# Altium schematic sheet IPMS 2.3 Module LCD.SchDoc("IPMS 2.3 Module LCD")
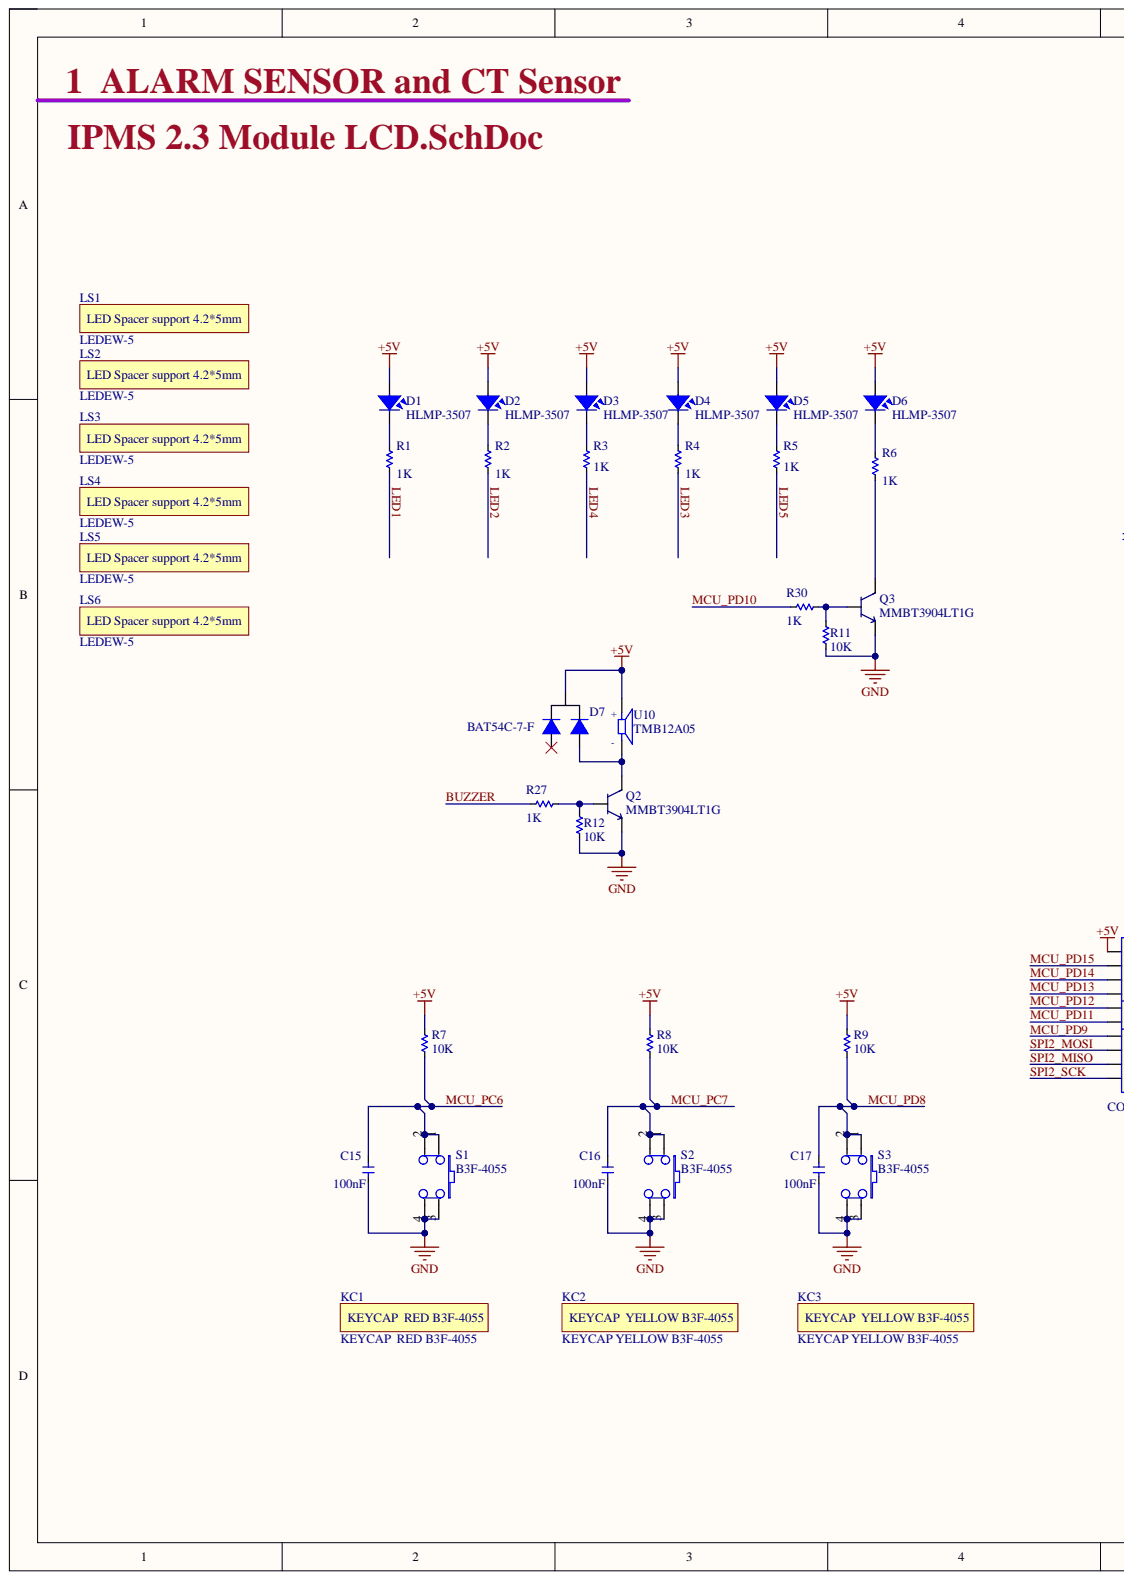
[diagram p0: IPMS 2.3 Module LCD.SchDoc, left]
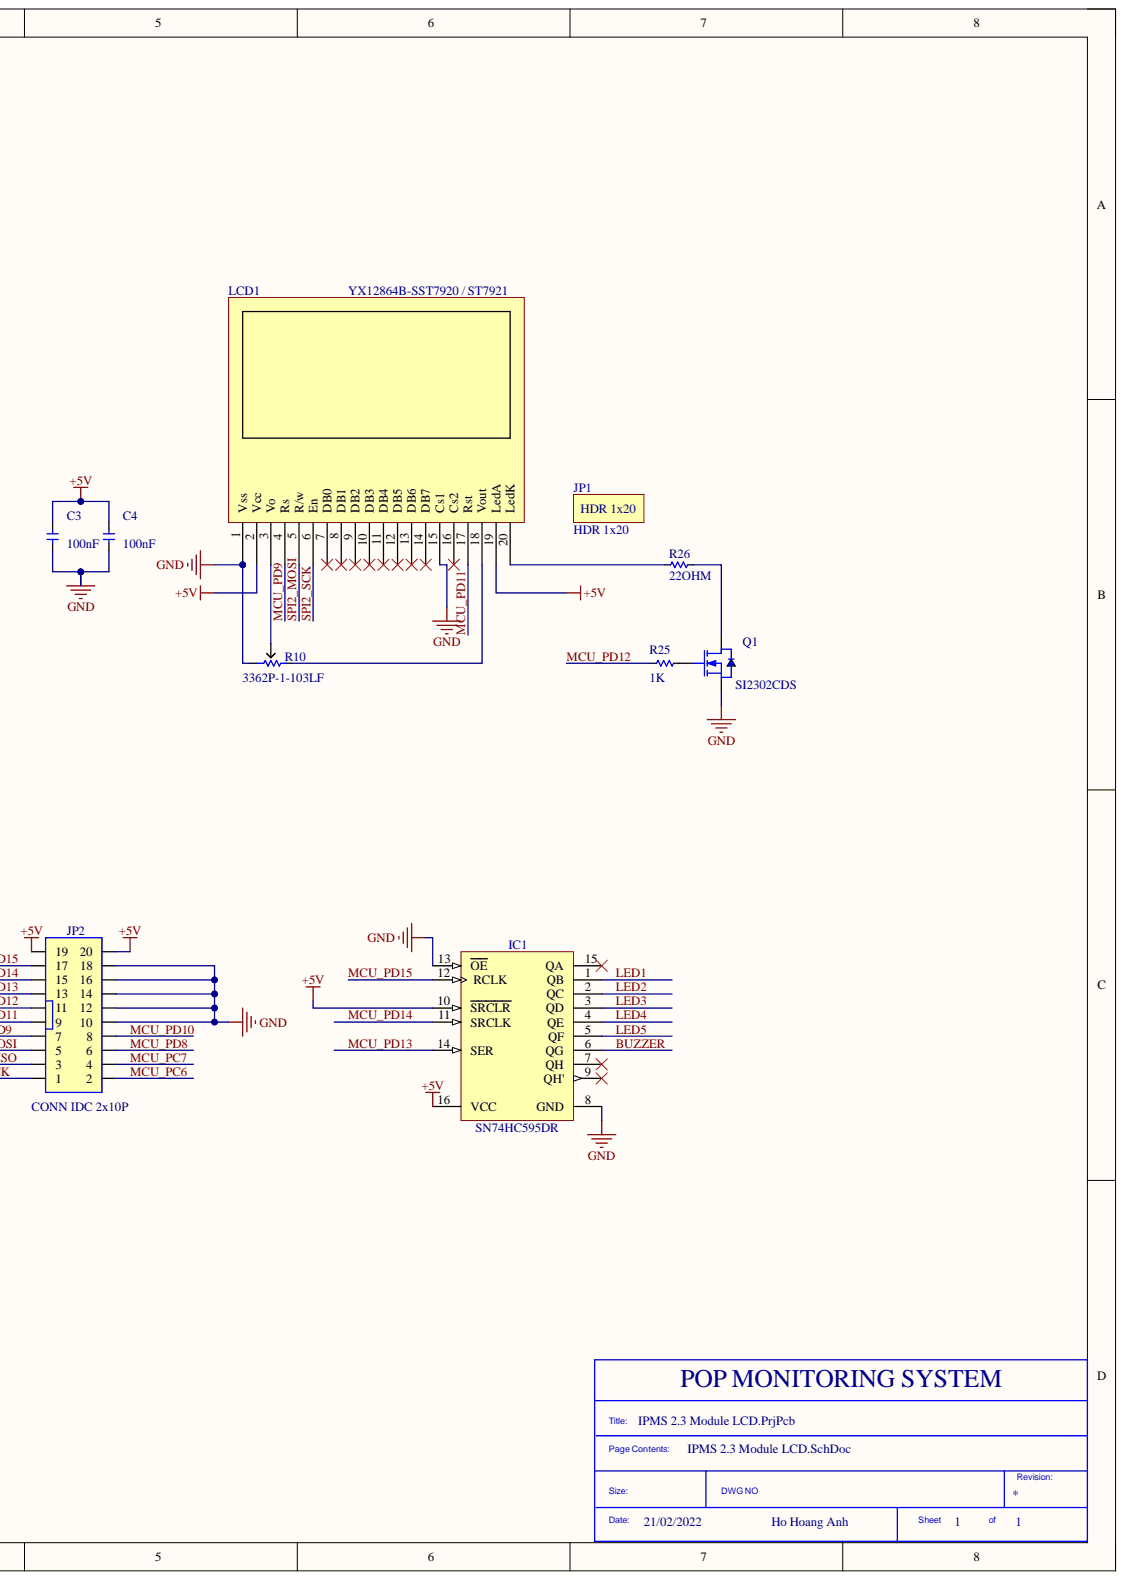
[diagram p1: IPMS 2.3 Module LCD.SchDoc, right]

## Parts
C3  100nF  [C_0603]
C4  100nF  [C_0603]
C15  100nF  [C_0603]
C16  100nF  [C_0603]
C17  100nF  [C_0603]
D1  HLMP-3507  [LED_5MM_GRE]
D2  HLMP-3507  [LED_5MM_GRE]
D3  HLMP-3507  [LED_5MM_GRE]
D4  HLMP-3507  [LED_5MM_GRE]
D5  HLMP-3507  [LED_5MM_GRE]
D6  HLMP-3507  [LED_5MM_GRE]
D7  BAT54C-7-F  [SOT-23-3]
IC1  SN74HC595DR  [SOIC-16_3.9x9.9x1.27P]
JP1  HDR 1x20  [HDR1X20]
JP2  CONN IDC 2x10P  [IDC-TH_20P-P2.54-V-R2-C10-S2.54]
KC1  KEYCAP  RED B3F-4055
KC2  KEYCAP YELLOW B3F-4055  Manufacturer Part Number: KEYCAP  YELLOW B3F-4055
KC3  KEYCAP YELLOW B3F-4055  Manufacturer Part Number: KEYCAP  YELLOW B3F-4055
LCD1  YX12864B-SST7920 / ST7921  [GLCD_12864B]
LS1  LEDEW-5  [Led Spacer support 4.2*5mm]
LS2  LEDEW-5  [Led Spacer support 4.2*5mm]
LS3  LEDEW-5  [Led Spacer support 4.2*5mm]
LS4  LEDEW-5  [Led Spacer support 4.2*5mm]
LS5  LEDEW-5  [Led Spacer support 4.2*5mm]
LS6  LEDEW-5  [Led Spacer support 4.2*5mm]
Q1  SI2302CDS  [SOT-23-3]
Q2  MMBT3904LT1G  [SOT-23-3]
Q3  MMBT3904LT1G  [SOT-23-3]
R1  1K  [R_0603]
R2  1K  [R_0603]
R3  1K  [R_0603]
R4  1K  [R_0603]
R5  1K  [R_0603]
R6  1K  [R_0603]
R7  10K  [R_0603]
R8  10K  [R_0603]
R9  10K  [R_0603]
R10  3362P-1-103LF  [RES-ADJ-TH_3362P]
R11  10K  [R_0603]
R12  10K  [R_0603]
R25  1K  [R_0603]
R26  22OHM  [R_1206]
R27  1K  [R_0603]
R30  1K  [R_0603]
S1  B3F-4055  [KEY-TH_4P-L12.0-W12.0-P5.08-LS12.50]
S2  B3F-4055  [KEY-TH_4P-L12.0-W12.0-P5.08-LS12.50]
S3  B3F-4055  [KEY-TH_4P-L12.0-W12.0-P5.08-LS12.50]
U10  TMB12A05  [Buzzer_P=7.62 12mm]

## Nets
+5V: C3.2 C4.2 D1.1 D2.1 D3.1 D4.1 D5.1 D6.1 D7.3 IC1.10(SRCLR) IC1.16(VCC) JP2.19 JP2.20 LCD1.2(Vcc) LCD1.19(LedA) R7.1 R8.1 R9.1 U10.P
GND: C3.1 C4.1 C15.2 C16.2 C17.2 IC1.8(GND) IC1.13(OE) JP2.10 JP2.12 JP2.14 JP2.16 JP2.18 LCD1.1(Vss) LCD1.15(Cs1) Q1.2 Q2.2 Q3.2 R10.3 R11.2 R12.2 S1.3 S1.4 S2.3 S2.4 S3.3 S3.4
BUZZER: IC1.6(QG) R27.2
LED1: IC1.1(QB) R1.2
LED2: IC1.2(QC) R2.2
LED3: IC1.3(QD) R4.2
LED4: IC1.4(QE) R3.2
LED5: IC1.5(QF) R5.2
MCU_PC6: C15.1 JP2.2 R7.2 S1.1 S1.2
MCU_PC7: C16.1 JP2.4 R8.2 S2.1 S2.2
MCU_PD8: C17.1 JP2.6 R9.2 S3.1 S3.2
MCU_PD9: JP2.7 LCD1.4(Rs)
MCU_PD10: JP2.8 R30.2
MCU_PD11: JP2.9 LCD1.17(Rst)
MCU_PD12: JP2.11 R25.1
MCU_PD13: IC1.14(SER) JP2.13
MCU_PD14: IC1.11(SRCLK) JP2.15
MCU_PD15: IC1.12(RCLK) JP2.17
SPI2_MISO: JP2.3
SPI2_MOSI: JP2.5 LCD1.5(R/w)
SPI2_SCK: JP2.1 LCD1.6(En)
NetD1_2: D1.2 R1.1
NetD2_2: D2.2 R2.1
NetD3_2: D3.2 R3.1
NetD4_2: D4.2 R4.1
NetD5_2: D5.2 R5.1
NetD6_2: D6.2 R6.1
NetD7_2: D7.2 Q2.3 U10.M
NetLCD1_3: LCD1.3(Vo) R10.2
NetLCD1_18: LCD1.18(Vout) R10.1
NetLCD1_20: LCD1.20(LedK) R26.2
NetQ1_1: Q1.1 R25.2
NetQ1_3: Q1.3 R26.1
NetQ2_1: Q2.1 R12.1 R27.1
NetQ3_1: Q3.1 R11.1 R30.1
NetQ3_3: Q3.3 R6.2

Not connected: D7 1; IC1 7(QH) 9(QH') 15(QA); LCD1 7(DB0) 8(DB1) 9(DB2) 10(DB3) 11(DB4) 12(DB5) 13(DB6) 14(DB7) 16(Cs2)

## Library data of the parts
C3 C4 C15-C17 = CC0603KRX7R9BB104: 100nF 50V X7R ±10% 0603 Multilayer Ceramic Capacitors MLCC - SMD/SMT ROHS; Manufacturer: YAGEO; SMT Assembly: Yes; Component Kind: Standard; LCSC Part Number: C14663; Manufacturer Part Number: CC0603KRX7R9BB104; Package: 0603; Library Name: IPMS 2.3 Module LCD.SCHLIB
D1-D6 = HLMP-3507: LED, 5MM, GREEN, 4.2MCD, 569NM; Manufacturer: Broadcom Avago; SMT Assembly: No; Component Kind: Standard; ComponentLink1Description: http://www.jameco.com/Jameco/Products/ProdDS/253770.pdf?src-supplier=Jameco; ComponentLink1URL: http://www.jameco.com/Jameco/Products/ProdDS/253770.pdf?src-supplier=Jameco; ComponentLink2Description: https://www.verical.com/server-webapp/html/getDatasheet?partID=128035&src-supplier=Verical; ComponentLink2URL: https://www.verical.com/server-webapp/html/getDatasheet?partID=128035&src-supplier=Verical; ComponentLink3Description: http://uk.rs-online.com/webdocs/0026/0900766b80026843.pdf?src-supplier=RSComponents; ComponentLink3URL: http://uk.rs-online.com/webdocs/0026/0900766b80026843.pdf?src-supplier=RSComponents; ComponentLink4Description: https://www.distrelec.biz/Web/Downloads/he/et/HLMP3x01-3507_eng_datasheet.pdf?mime=application%2Fpdf&src-supplier=Distrelec; ComponentLink4URL: https://www.distrelec.biz/Web/Downloads/he/et/HLMP3x01-3507_eng_datasheet.pdf?mime=application%2Fpdf&src-supplier=Distrelec; ComponentLink5Description: http://www.rapidonline.com/pdf/55-2820.pdf?src-supplier=Rapid+Electronics; ComponentLink5URL: http://www.rapidonline.com/pdf/55-2820.pdf?src-supplier=Rapid+Electronics; ComponentLink6Description: http://www.produktinfo.conrad.com/datenblaetter/175000-199999/180622-da-01-en-LED_5_MM_HLMP_3507_GRUEN.pdf?src-supplier=Conrad; ComponentLink6URL: http://www.produktinfo.conrad.com/datenblaetter/175000-199999/180622-da-01-en-LED_5_MM_HLMP_3507_GRUEN.pdf?src-supplier=Conrad; LCSC Part Number: C188723; Manufacturer Part Number: HLMP-3507; Package: Through hole; Library Name: IPMS 2.3 Module LCD.SCHLIB
D7 = BAT54C-7-F: DIODE ARRAY SCHOTTKY 30V SOT23-3; Manufacturer: Diodes; SMT Assembly: Yes; Component Kind: Standard; ComponentLink1Description: https://www.diodes.com/assets/Datasheets/ds11005.pdf?src-supplier=Digi-Key; ComponentLink1URL: https://www.diodes.com/assets/Datasheets/ds11005.pdf?src-supplier=Digi-Key; ComponentLink2Description: http://www.farnell.com/datasheets/1911820.pdf?src-supplier=Element14; ComponentLink2URL: http://www.farnell.com/datasheets/1911820.pdf?src-supplier=Element14; ComponentLink3Description: https://www.verical.com/server-webapp/html/getDatasheet?partID=29884&src-supplier=Verical; ComponentLink3URL: https://www.verical.com/server-webapp/html/getDatasheet?partID=29884&src-supplier=Verical; LCSC Part Number: C134405; Manufacturer Part Number: BAT54C-7-F; Package: SOT-23-3; Library Name: IPMS 2.3 Module LCD.SCHLIB
IC1 = SN74HC595DR: 74HC Shift Register SOIC-16_150mil Registers ROHS; Manufacturer: Texas Instruments; SMT Assembly: Yes; Component Kind: Standard; LCSC Part Number: C10092; Manufacturer Part Number: SN74HC595DR; Package: SOIC-16_3.9x9.9x1.27P; Library Name: IPMS 2.3 Module LCD.SCHLIB
JP1 = 61302011121: Connector Header Through Hole 20 position 0.100" (2.54mm); Manufacturer: Wurth Electronics; SMT Assembly: No; Component Kind: Standard; LCSC Part Number: N/A; Manufacturer Part Number: 61302011121; Package: HDR P=2.54; Library Name: IPMS 2.3 Module LCD.SCHLIB
JP2 = IDC 2X10P Push-Pull: 2.54mm Straight Push - Pull 2.54mm 2 Plugin,P=2.54mm IDC Connectors ROHSM; Manufacturer: BOOMELE(Boom Precision Elec); Manufacturer Part Number: 302-S201; SMT Assembly: No; Component Kind: Standard; LCSC Part Number: C3405; Package: 2.54 2X10P; Library Name: IPMS 2.3 Module LCD.SCHLIB
KC1 = KEYCAP  RED B3F-4055: Keycap red for tact switch B3f-4055 12x12mm; Manufacturer: China; SMT Assembly: No; Component Kind: Standard; LCSC Part Number: N/A; Manufacturer Part Number: KEYCAP  RED B3F-4055; Package: N/A; Library Name: IPMS 2.3 Module LCD.SCHLIB
KC2 KC3 = KEYCAP YELLOW B3F-4055: Keycap yellow for tact switch B3f-4055 12x12mm; Manufacturer: China; SMT Assembly: No; Component Kind: Standard; LCSC Part Number: N/A; Package: N/A; Library Name: IPMS 2.3 Module LCD.SCHLIB
LCD1 = YX12864B: LCD12864B-V2.0 128x64 DOTS, Graphic LCD module, White/ Blue, 5V Parallel / SPI Driver ST7290/ST7291; Manufacturer: TAKAMISAWA; SMT Assembly: No; Component Kind: Standard; LCSC Part Number: N/A; Manufacturer Part Number: YX12864B; Package: Module; Library Name: IPMS 2.3 Module LCD.SCHLIB
LS1-LS6 = LEDEW-5: Photoelectric Accessories LED 3mm Spacer Support 4.2*5mm; Manufacturer: HIWA; SMT Assembly: No; Component Kind: Standard; LCSC Part Number: C116904; Manufacturer Part Number: LEDEW-5; Package: N/A; Library Name: IPMS 2.3 Module LCD.SCHLIB
Q1 = SI2302CDS-T1-GE3: MOSFET N-CH 20V 2.6A SOT-23; Manufacturer: Vishay Siliconix; SMT Assembly: Yes; Component Kind: Standard; LCSC Part Number: C10488; Manufacturer Part Number: Si2302CDS-T1-GE3; Package: SOT-23-3; Library Name: IPMS 2.3 Module LCD.SCHLIB
Q2 Q3 = MMBT3904LT1G: MMBT3904 TRANSISTORS (NPN/PNP) NPN 200MA 40V SOT-23(SOT-23-3) ROHS; Manufacturer: Onsemi; SMT Assembly: Yes; Component Kind: Standard; LCSC Part Number: C81464; Manufacturer Part Number: MMBT3904LT1G; Package: SOT-23-3; Library Name: IPMS 2.3 Module LCD.SCHLIB
R1-R6 R25 R27 R30 = 0603WAF1001T5E: 1KOHMS ±1% 1/10W 0603 ROHS; Manufacturer: Uniroyal Elec; SMT Assembly: Yes; Component Kind: Standard; LCSC Part Number: C21190; Manufacturer 2: Yageo; Manufacturer Part Number: 0603WAF1001T5E; Manufacturer Part Number 2: RC0603FR-071KP; Package: 0603; Pricing 1: 1=0.00210, 10=0.0021 , 100=0.0021, 1000=0.00180 (LCSC); Library Name: IPMS 2.3 Module LCD.SCHLIB
R7-R9 R11 R12 = 0603WAF1002T5E: 10KOHMS ±1% 1/10W 0603 ROHS; Manufacturer: Uniroyal Elec; SMT Assembly: Yes; Component Kind: Standard; LCSC Part Number: C25804; Manufacturer 2: Yageo; Manufacturer Part Number: 0603WAF1002T5E; Manufacturer Part Number 2: RC0603FR-0710KL; Package: 0603; Pricing 1: 1=0.00160, 10=0.00160, 100=0.00160, 1000=0.00130 (LCSC); Library Name: IPMS 2.3 Module LCD.SCHLIB
R10 = 3362P-1-103LF: 10K ±10% ±100ppm/℃ 3362P Precision Potentiometer RoHS; Manufacturer: BOURNS; SMT Assembly: No; Component Kind: Standard; Datasheet: https://datasheet.lcsc.com/szlcsc/1811151535_BOURNS-3362P-1-103LF_C58159.pdf; LCSC Part Number: C58159; Manufacturer Part Number: 3362P-1-103LF; Package: 3362P; Library Name: IPMS 2.3 Module LCD.SCHLIB
R26 = 1206W4F220JT5E: 22Ω 1206 ±1% 1/4W Thick Film Resistors 200V ±100ppm/℃ -55℃~+155℃; Manufacturer: Uniroyal Elec; SMT Assembly: Yes; Component Kind: Standard; LCSC Part Number: C17958; Manufacturer Part Number: 1206W4F220JT5E; Package: 1206; Library Name: IPMS 2.3 Module LCD.SCHLIB
S1-S3 = B3F-4055: 12mm 7.3mm Square Plunger 50mA Straight 12mm SPST 12V Plugin Tactile Switches ROHS; Manufacturer: Omron Electronics Inc-EMC Div; SMT Assembly: No; Component Kind: Standard; LCSC Part Number: C231717; Manufacturer Part Number: B3F-4055; Package: KEY-TH; Library Name: IPMS 2.3 Module LCD.SCHLIB
U10 = BUZ_TMB12A05: Magnetic YES 2300±400Hz 85dB @ 5V, 10cm 5V Φ12mm Through Hole 7.62 Buzzers RoHS; Manufacturer: Jiangsu Huaneng Elec; SMT Assembly: No; Component Kind: Standard; LCSC Part Number: C96093; Manual Assembly: Yes; Manufacturer Part Number: TMB12A05; Package: Through Hole 7.62; Pricing 1: 1=0.19530 (LCSC); Library Name: IPMS 2.3 Module LCD.SCHLIB
